# Altium schematic sheet Sheet1.SchDoc(Sheet1)
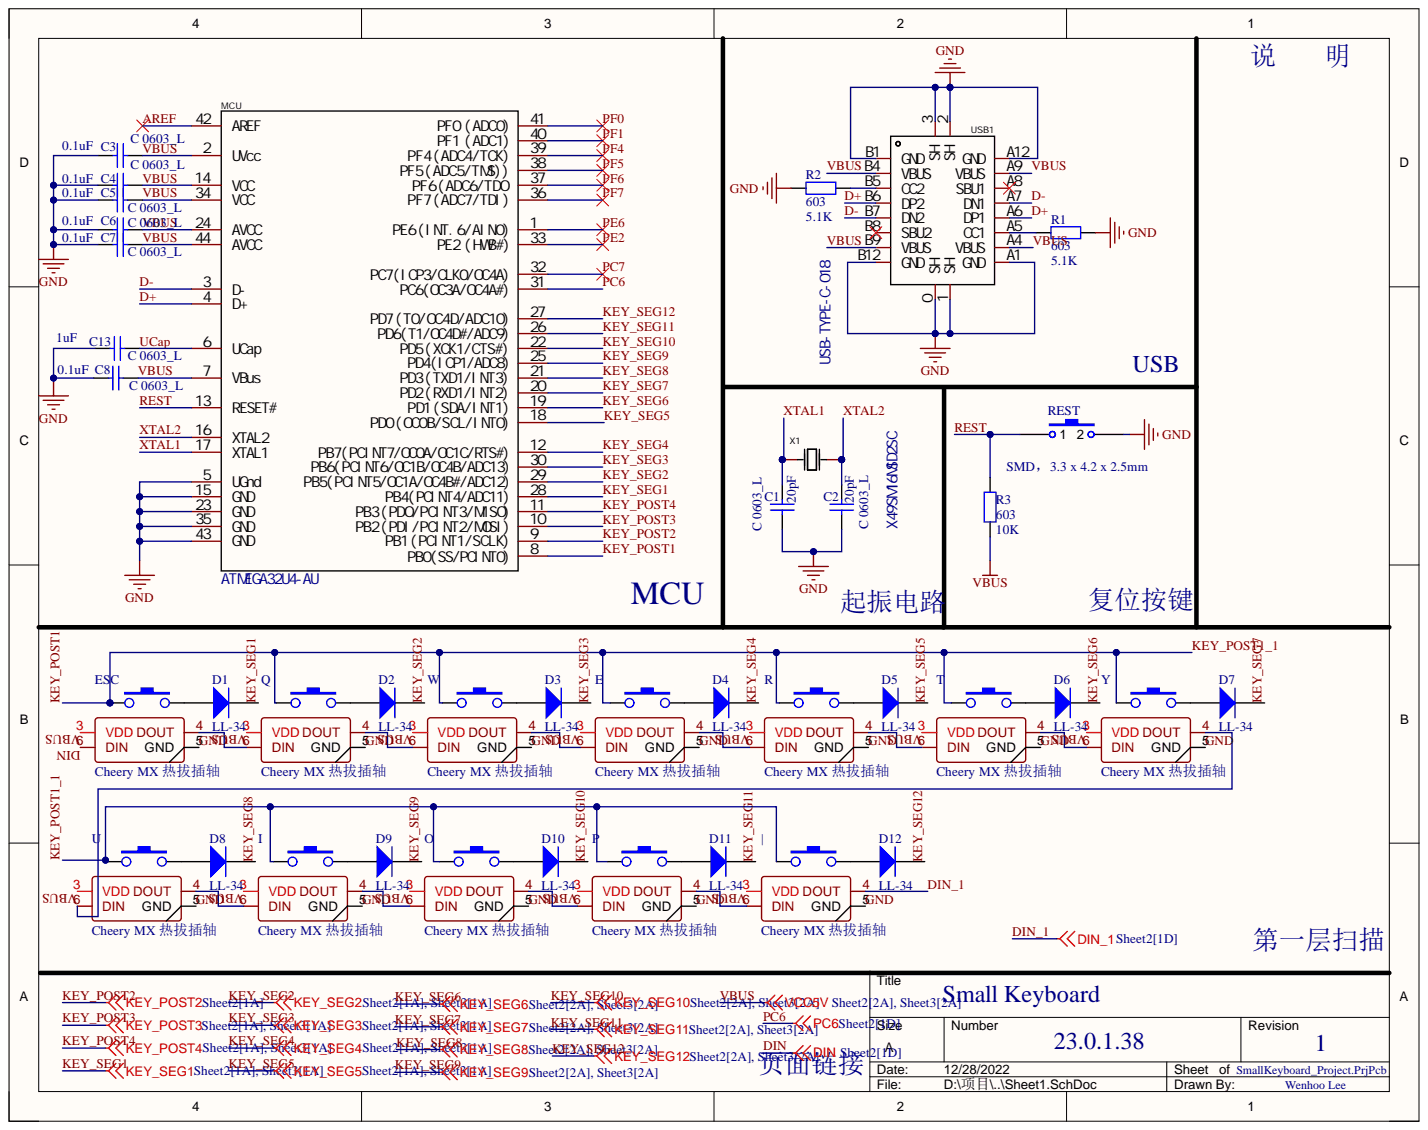
[diagram p0: Sheet1.SchDoc]

## Parts
C1  C 0603_L  value 20pF  [C 0603_L]
C2  C 0603_L  value 20pF  [C 0603_L]
C3  C 0603_L  value 0.1uF  [C 0603_L]
C4  C 0603_L  value 0.1uF  [C 0603_L]
C5  C 0603_L  value 0.1uF  [C 0603_L]
C6  C 0603_L  value 0.1uF  [C 0603_L]
C7  C 0603_L  value 0.1uF  [C 0603_L]
C8  C 0603_L  value 0.1uF  [C 0603_L]
C13  C 0603_L  value 1uF  [C 0603_L]
D1  LL-34  [LL-34_L3.7-W1.6-RD]
D2  LL-34  [LL-34_L3.7-W1.6-RD]
D3  LL-34  [LL-34_L3.7-W1.6-RD]
D4  LL-34  [LL-34_L3.7-W1.6-RD]
D5  LL-34  [LL-34_L3.7-W1.6-RD]
D6  LL-34  [LL-34_L3.7-W1.6-RD]
D7  LL-34  [LL-34_L3.7-W1.6-RD]
D8  LL-34  [LL-34_L3.7-W1.6-RD]
D9  LL-34  [LL-34_L3.7-W1.6-RD]
D10  LL-34  [LL-34_L3.7-W1.6-RD]
D11  LL-34  [LL-34_L3.7-W1.6-RD]
D12  LL-34  [LL-34_L3.7-W1.6-RD]
E  Cheery MX 热拔插轴  [MX_SW-HOTPLUG]
ESC  Cheery MX 热拔插轴  [MX_SW-HOTPLUG]
I  Cheery MX 热拔插轴  [MX_SW-HOTPLUG]
MCU  ATMEGA32U4-AU  [QFP-44_L10.0-W10.0-P0.80-LS13.2-BL]
O  Cheery MX 热拔插轴  [MX_SW-HOTPLUG]
P  Cheery MX 热拔插轴  [MX_SW-HOTPLUG]
Q  Cheery MX 热拔插轴  [MX_SW-HOTPLUG]
R  Cheery MX 热拔插轴  [MX_SW-HOTPLUG]
R1  603  value 5.1K  [0603P]
R2  603  value 5.1K  [0603P]
R3  603  value 10K  [R 0603_L]
REST  SMD，3.3 x 4.2 x 2.5mm  [SMD-3.2*4.2]
T  Cheery MX 热拔插轴  [MX_SW-HOTPLUG]
U  Cheery MX 热拔插轴  [MX_SW-HOTPLUG]
USB1  USB-TYPE-C-018  [USB-C_SMD-TYPE-C-31-M-12]
W  Cheery MX 热拔插轴  [MX_SW-HOTPLUG]
X1  X49SM16MSD2SC  [HC-49S_L11.4-W4.8]
Y  Cheery MX 热拔插轴  [MX_SW-HOTPLUG]
|  Cheery MX 热拔插轴  [MX_SW-HOTPLUG]

## Nets
AREF: MCU.42(AREF)
D+: MCU.4(D+) USB1.A6(DP1) USB1.B6(DP2)
D-: MCU.3(D-) USB1.A7(DN1) USB1.B7(DN2)
DIN: ESC.6(DIN)
DIN_1: |.4(DOUT)
GND: C1.1 C2.1 C3.1 C4.1 C5.1 C6.1 C7.1 C8.1 C13.1 E.5(GND) ESC.5(GND) I.5(GND) MCU.5(UGnd) MCU.15(GND) MCU.23(GND) MCU.35(GND) MCU.43(GND) O.5(GND) P.5(GND) Q.5(GND) R.5(GND) R1.2 R2.1 REST.2 T.5(GND) U.5(GND) USB1.0(SH) USB1.1(SH) USB1.2(SH) USB1.3(SH) USB1.A1(GND) USB1.A12(GND) USB1.B1(GND) USB1.B12(GND) W.5(GND) Y.5(GND) |.5(GND)
KEY_POST1: E.1 ESC.1 I.1 MCU.8(PB0(SS/PCINT0)) O.1 P.1 Q.1 R.1 T.1 U.1 W.1 Y.1 |.1
KEY_POST2: MCU.9(PB1_(PCINT1/SCLK))
KEY_POST3: MCU.10
KEY_POST4: MCU.11
KEY_SEG1: D1.2 MCU.28(PB4(PCINT4/ADC11))
KEY_SEG2: D2.2 MCU.29(PB5(PCINT5/OC1A/OC4B#/ADC12))
KEY_SEG3: D3.2 MCU.30(PB6(PCINT6/OC1B/OC4B/ADC13))
KEY_SEG4: D4.2 MCU.12(PB7(PCINT7/OC0A/OC1C/RTS#))
KEY_SEG5: D5.2 MCU.18(PD0_(OC0B/SCL/INT0))
KEY_SEG6: D6.2 MCU.19(PD1_(SDA/INT1))
KEY_SEG7: D7.2 MCU.20(PD2_(RXD1/INT2))
KEY_SEG8: D8.2 MCU.21(PD3_(TXD1/INT3))
KEY_SEG9: D9.2 MCU.25(PD4(ICP1/ADC8))
KEY_SEG10: D10.2 MCU.22(PD5_(XCK1/CTS#))
KEY_SEG11: D11.2 MCU.26(PD6(T1/OC4D#/ADC9))
KEY_SEG12: D12.2 MCU.27(PD7_(T0/OC4D/ADC10))
PC6: MCU.31(PC6(OC3A/OC4A#))
PC7: MCU.32(PC7(ICP3/CLK0/OC4A))
PE2: MCU.33(PE2_(HWB#))
PE6: MCU.1(PE6_(INT.6/AIN0))
PF0: MCU.41(PF0_(ADC0))
PF1: MCU.40(PF1_(ADC1))
PF4: MCU.39(PF4_(ADC4/TCK))
PF5: MCU.38(PF5_(ADC5/TMS)))
PF6: MCU.37(PF6_(ADC6/TDO)
PF7: MCU.36(PF7_(ADC7/TDI))
REST: MCU.13(RESET#) R3.2 REST.1
UCap: C13.2 MCU.6(UCap)
VBUS: C3.2 C4.2 C5.2 C6.2 C7.2 C8.2 E.3(VDD) ESC.3(VDD) I.3(VDD) MCU.2(UVcc) MCU.7(VBus) MCU.14(VCC) MCU.24(AVCC) MCU.34(VCC) MCU.44(AVCC) O.3(VDD) P.3(VDD) Q.3(VDD) R.3(VDD) R3.1 T.3(VDD) U.3(VDD) USB1.A4(VBUS) USB1.A9(VBUS) USB1.B4(VBUS) USB1.B9(VBUS) W.3(VDD) Y.3(VDD) |.3(VDD)
XTAL1: C1.2 MCU.17(XTAL1) X1.1
XTAL2: C2.2 MCU.16(XTAL2) X1.2
NetD1_1: D1.1 ESC.2
NetD2_1: D2.1 Q.2
NetD3_1: D3.1 W.2
NetD4_1: D4.1 E.2
NetD5_1: D5.1 R.2
NetD6_1: D6.1 T.2
NetD7_1: D7.1 Y.2
NetD8_1: D8.1 U.2
NetD9_1: D9.1 I.2
NetD10_1: D10.1 O.2
NetD11_1: D11.1 P.2
NetD12_1: D12.1 |.2
NetE_4: E.4(DOUT) R.6(DIN)
NetE_6: E.6(DIN) W.4(DOUT)
NetESC_4: ESC.4(DOUT) Q.6(DIN)
NetI_4: I.4(DOUT) O.6(DIN)
NetI_6: I.6(DIN) U.4(DOUT)
NetO_4: O.4(DOUT) P.6(DIN)
NetP_4: P.4(DOUT) |.6(DIN)
NetQ_4: Q.4(DOUT) W.6(DIN)
NetR1_1: R1.1 USB1.A5(CC1)
NetR2_2: R2.2 USB1.B5(CC2)
NetR_4: R.4(DOUT) T.6(DIN)
NetT_4: T.4(DOUT) Y.6(DIN)
NetU_6: U.6(DIN) Y.4(DOUT)

Not connected: USB1 A8(SBU1) B8(SBU2)

## Library data of the parts
C1-C8 C13 = C 0603_L: 贴片电容器（Capacitor）; Component Kind: Standard; LatestRevisionDate: 17-Jul-2002; LatestRevisionNote: Re-released for DXP Platform.; Library Name: BaseLib.SchLib; PackageDescription: Radial Cap, Thru-Hole; 2 Leads; 0.3 in Pin Spacing; PackageReference: RAD-0.3; Published: 8-Jun-2000; Publisher: Altium Limited
D1-D12 = LL4148: 开关二极管（Switching diode）; Code_JEDEC: DO-214-AB; Component Kind: Standard; LatestRevisionDate: 17-Jul-2002; LatestRevisionNote: Re-released for DXP Platform.; Library Name: BaseLib.SchLib; PackageDescription: DO-214-AB/SMC; 2 C-Bend Leads; Body 7.9 x 5.9 mm, inc. leads (LxW); PackageReference: SMC; PackageVersion: Sep-1996; Published: 8-Jun-2000; Publisher: Altium Limited
E ESC I O P Q R T U W Y | = Cheery MX 热拔插轴: 机械键盘轴（Cheery MX）; Component Kind: Standard; Library Name: BaseLib.SchLib
MCU = ATMEGA32U4-AU: MCU，ATMEGA32U4-AU; Manufacturer: MICROCHIP(美国微芯); ADC (Units/Channels/bits): 1@x12ch/10bit; Add into BOM: yes; CPU Core: AVR; EEPROM/Data FLASH Size: 1KB; GPIO Ports Number: 26; Internal Oscillator: 有; Maximum Frequency: 16MHz; Peripherals / Functions / Protocol Stacks: 欠压检测/复位；看门狗；POR；PWM; Program FLASH Size: 16K@x16bit; RAM Size: 2.5KB; Supply Voltage Range: 2.7V~5.5V; Component Kind: Standard; Convert to PCB: yes; Datasheet: https://atta.szlcsc.com/upload/public/pdf/source/20150811/1457707338800.pdf; Device: ATMEGA32U4-AU; JLCPCB Part Class: Extended Part; LCSC Part Name: AVR 16MHz 闪存：16K@x16bit RAM：2.5KB; Library Name: BaseLib.SchLib; Manufacturer Part: ATMEGA32U4-AU; Name: ATMEGA32U4-AU; Operating Temperature Range: -40℃~+85℃; PCB3D: QFP-44_L10.0-W10.0-H1.2-LS12-P0.80; Supplier: LCSC; Supplier Footprint: QFP-44_10x10x08P; Supplier Part: C44854; Symbol: ATMEGA32U4-AU
R1 R2 = R 0603_P: 贴片电阻（Resistor）; Component Kind: Standard; LatestRevisionDate: 17-Jul-2002; LatestRevisionNote: Re-released for DXP Platform.; Library Name: BaseLib.SchLib; PackageDescription: Axial Device, Thru-Hole; 2 Leads; 0.4 in Pin Spacing; PackageReference: AXIAL-0.4; Published: 8-Jun-2000; Publisher: Altium Limited
R3 = R 0603_L: 贴片电阻（Resistor）; Component Kind: Standard; LatestRevisionDate: 17-Jul-2002; LatestRevisionNote: Re-released for DXP Platform.; Library Name: BaseLib.SchLib; PackageDescription: Axial Device, Thru-Hole; 2 Leads; 0.4 in Pin Spacing; PackageReference: AXIAL-0.4; Published: 8-Jun-2000; Publisher: Altium Limited
REST = 260GF: 轻触开关（Touch Switch）; Component Kind: Standard; LatestRevisionDate: 17-Jul-2002; LatestRevisionNote: Re-released for DXP Platform.; Library Name: BaseLib.SchLib; PackageDescription: Switch; 2 Leads; PackageReference: SPST-2; Published: 24-Mar-1999; Publisher: Altium Limited
USB1 = USB-TYPE-C-018: 通用通信总线接口（USB）,16pin; Manufacturer: DEALON(德艺隆); Add into BOM: yes; Connector Type: Type-C; Current Rating - Power (Max): 3A; Gender: 母座; Number of Contacts: 16; Number of Ports: 1; Component Kind: Standard; Convert to PCB: yes; Datasheet: https://atta.szlcsc.com/upload/public/pdf/source/20211217/CCD3D517E355E8E0CC52ABD8D5784AD8.pdf; Device: USB-TYPE-C-018; JLCPCB Part Class: Extended Part; LCSC Part Name: USB-TYPE-C-018; Library Name: BaseLib.SchLib; Manufacturer Part: USB-TYPE-C-018; Mounting Style: 卧贴; Name: USB-TYPE-C-018; Operating Temperature Range: -25℃~+85℃; PCB3D: USB-C_SMD-TYPE-C-31-M-12; Standard: -; Supplier: LCSC; Supplier Footprint: SMD; Supplier Part: C2927038; Symbol: USB-TYPE-C-018
X1 = X49SM16MSD2SC: 无源晶振，2PIN; Manufacturer: YXC(扬兴晶振); Add into BOM: yes; Crystal Type: 49SMD; Frequency Stability: ±30ppm; Frequency Tolerance: ±20ppm; Load Capacitance: 20pF; Name: 16MHz; Value: 16MHz; Component Kind: Standard; Convert to PCB: yes; Datasheet: https://atta.szlcsc.com/upload/public/pdf/source/20210329/C106809_0E91E60850A780B284B63D653DC40EA4.pdf; Device: X49SM16MSD2SC; JLCPCB Part Class: Extended Part; LCSC Part Name: HC-49SMD 16MHz ±20ppm 20pF; Library Name: BaseLib.SchLib; Manufacturer Part: X49SM16MSD2SC; Operating Temperature: -20℃~+70℃; PCB3D: HC-49S_L11.4-W1.8-H3.0-LS12.7; Supplier: LCSC; Supplier Footprint: HC-49S-SMD-2P; Supplier Part: C12676; Symbol: X49SM16MSD2SC
